# Altium schematic sheet SAM70.SchDoc(U_SAM70)
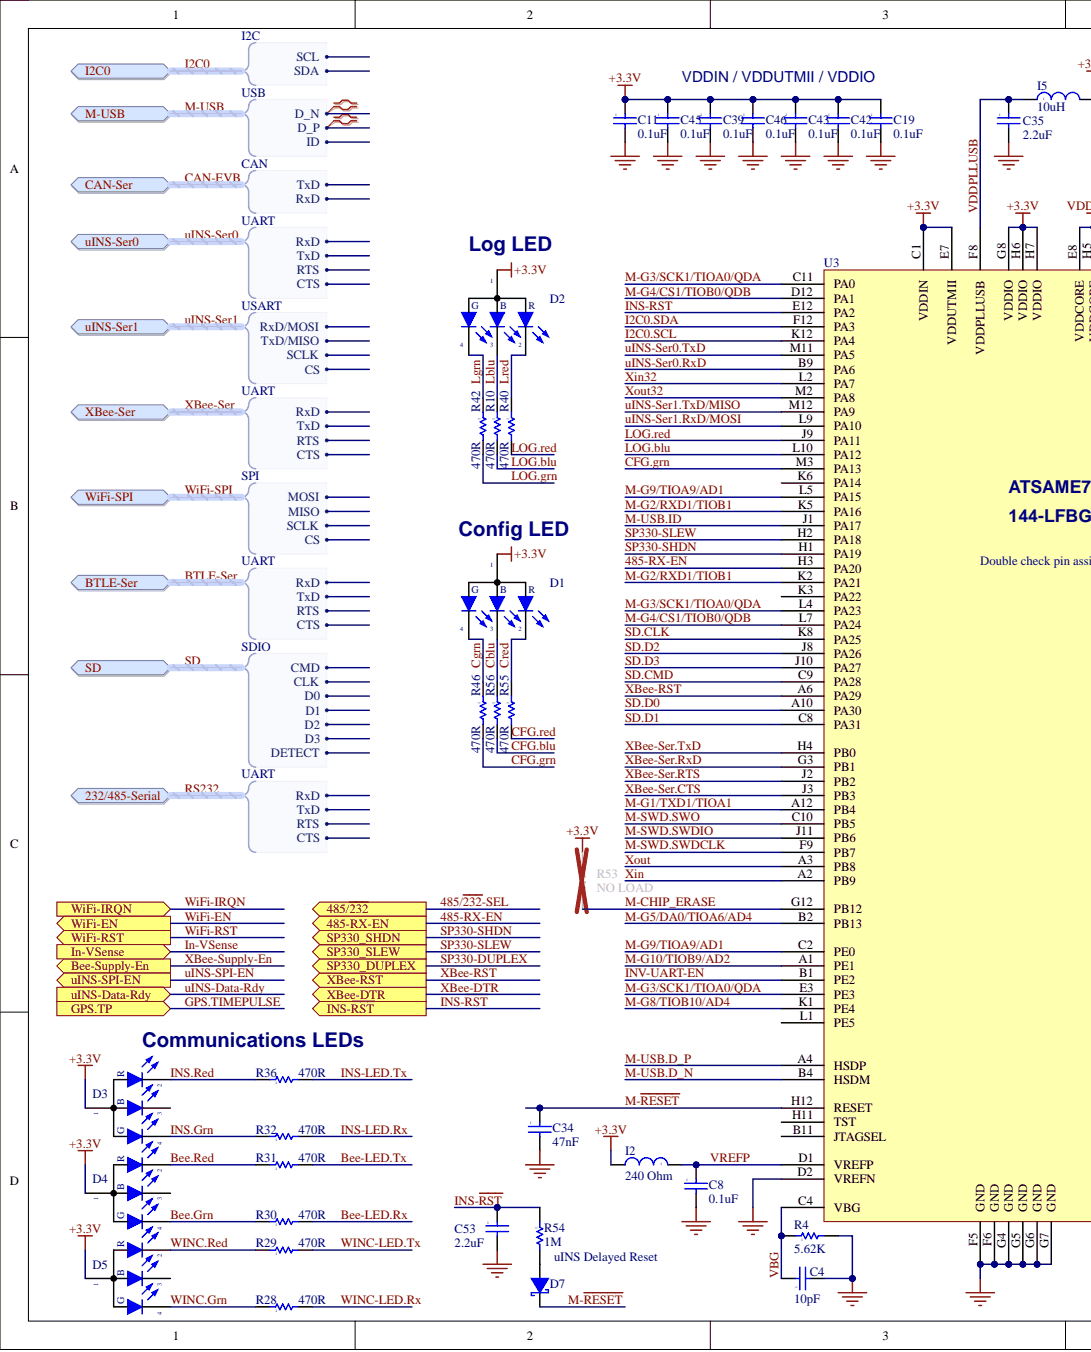
[diagram p0: SAM70.SchDoc, left]
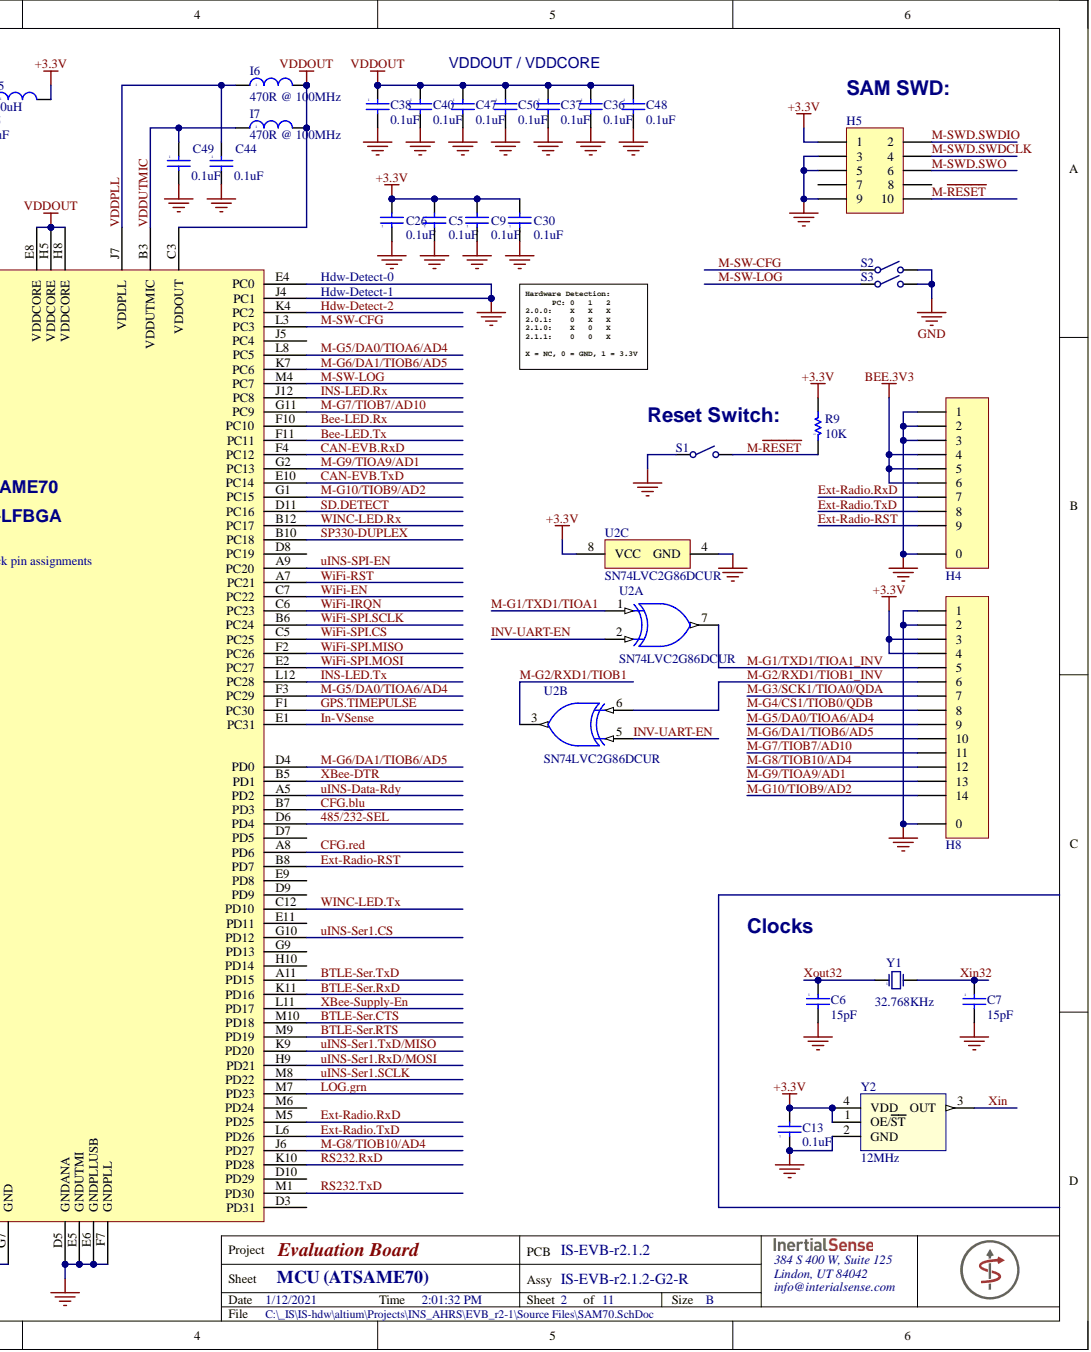
[diagram p1: SAM70.SchDoc, right]

## Parts
C4  value 10pF  Capacitance: 10pF  [0402]  (hidden comment: Cap)
C5  value 0.1uF  [0402]  (hidden comment: Cap)
C6  value 15pF  Capacitance: 15pF  [0402]  (hidden comment: Cap)
C7  value 15pF  Capacitance: 15pF  [0402]  (hidden comment: Cap)
C8  value 0.1uF  [0201]  (hidden comment: Cap)
C9  value 0.1uF  [0402]  (hidden comment: Cap)
C11  value 0.1uF  [0201]  (hidden comment: Cap)
C13  value 0.1uF  [0402]  (hidden comment: Cap)
C19  value 0.1uF  [0201]  (hidden comment: Cap)
C26  value 0.1uF  [0402]  (hidden comment: Cap)
C30  value 0.1uF  [0402]  (hidden comment: Cap)
C34  value 47nF  Capacitance: 47nF  [0402]  (hidden comment: Cap)
C35  Capacitance: 2.2uF  [0603]  (hidden comment: Cap)
C36  value 0.1uF  [0201]  (hidden comment: Cap)
C37  value 0.1uF  [0201]  (hidden comment: Cap)
C38  value 0.1uF  [0201]  (hidden comment: Cap)
C39  value 0.1uF  [0201]  (hidden comment: Cap)
C40  value 0.1uF  [0201]  (hidden comment: Cap)
C42  value 0.1uF  [0201]  (hidden comment: Cap)
C43  value 0.1uF  [0201]  (hidden comment: Cap)
C44  value 0.1uF  [0201]  (hidden comment: Cap)
C45  value 0.1uF  [0201]  (hidden comment: Cap)
C46  value 0.1uF  [0201]  (hidden comment: Cap)
C47  value 0.1uF  [0201]  (hidden comment: Cap)
C48  value 0.1uF  [0201]  (hidden comment: Cap)
C49  value 0.1uF  [0201]  (hidden comment: Cap)
C50  value 0.1uF  [0201]  (hidden comment: Cap)
C53  Capacitance: 2.2uF  [0603]  (hidden comment: Cap)
D1  LED-RGB  [LED0404]  (value not drawn)
D2  LED-RGB  [LED0404]  (value not drawn)
D3  LED-RGB  [LED0404]  (value not drawn)
D4  LED-RGB  [LED0404]  (value not drawn)
D5  LED-RGB  [LED0404]  (value not drawn)
D7  Diode - Schottky  [D0603B]  (value not drawn)
H4  Hdr - 9pin - mount  [SM09B-GHS-TB(LF)(SN)]  (value not drawn)
H5  Hdr - 5x2pin  [FTSH-105-01-L-DV-K-A-P]  (value not drawn)
H8  Hdr - 14pin - mount  [SM14B-GHS-TB(LF)(SN)]  (value not drawn)
I2  value 240 Ohm  [0201]  (hidden comment: Inductor)
I5  Inductance: 10uH  [0806]  (hidden comment: Inductor)
I6  value 470R @ 100MHz  Frequency: 100MHz  Impedance: 470R  [0402]  (hidden comment: Inductor)
I7  value 470R @ 100MHz  Frequency: 100MHz  Impedance: 470R  [0402]  (hidden comment: Inductor)
R4  value 5.62K  [R0402]  (hidden comment: Res)
R9  value 10K  [R0402]  (hidden comment: Res)
R10  value 470R  Resistance: 470R  [R0402]  (hidden comment: Res)
R28  value 470R  Resistance: 470R  [R0402]  (hidden comment: Res)
R29  value 470R  Resistance: 470R  [R0402]  (hidden comment: Res)
R30  value 470R  Resistance: 470R  [R0402]  (hidden comment: Res)
R31  value 470R  Resistance: 470R  [R0402]  (hidden comment: Res)
R32  value 470R  Resistance: 470R  [R0402]  (hidden comment: Res)
R36  value 470R  Resistance: 470R  [R0402]  (hidden comment: Res)
R40  value 470R  Resistance: 470R  [R0402]  (hidden comment: Res)
R42  value 470R  Resistance: 470R  [R0402]  (hidden comment: Res)
R46  value 470R  Resistance: 470R  [R0402]  (hidden comment: Res)
R53  value NO LOAD  Resistance (Ohms): NO LOAD  [R0402]  (hidden comment: Res)
R54  value 1M  [R0402]  (hidden comment: Res)
R55  value 470R  Resistance: 470R  [R0402]  (hidden comment: Res)
R56  value 470R  Resistance: 470R  [R0402]  (hidden comment: Res)
S1  SPST Switch  [PTS810]  (value not drawn)
S2  SPST Switch  [PTS810]  (value not drawn)
S3  SPST Switch  [PTS810]  (value not drawn)
U2  SN74LVC2G86DCUR  [DCU0008A_N]  (parts A,B,C)
U3  ATSAME70-144  [BGA144C80P12X12_1000X1000X140]
Y1  value 32.768KHz  [CRYMP2012X06L]  (hidden comment: Crystal)
Y2  value 12MHz  Frequency: 12MHz  [ABM10_2.5_x_2.0]  (hidden comment: Oscillator2)

## Ports
232/485-Serial, 232/485-Serial.CTS, 232/485-Serial.RTS, 232/485-Serial.RxD, 232/485-Serial.TxD, 485-RX-EN, 485/~{232}, Bee-Supply-En, BTLE-Ser, BTLE-Ser.CTS, BTLE-Ser.RTS, BTLE-Ser.RxD, BTLE-Ser.TxD, CAN-Ser, CAN-Ser.RxD, CAN-Ser.TxD, GPS.TP, I2C0, I2C0.SCL, I2C0.SDA, In-VSense, INS-~{RST}, M-USB, M-USB.D_N, M-USB.D_P, M-USB.ID, SD, SD.CLK, SD.CMD, SD.D0, SD.D1, SD.D2, SD.D3, SD.DETECT, SP330_DUPLEX, uINS-Data-Rdy, uINS-Ser0, uINS-Ser0.CTS, uINS-Ser0.RTS, uINS-Ser0.RxD, uINS-Ser0.TxD, uINS-Ser1, uINS-Ser1.CS, uINS-Ser1.RxD/MOSI, uINS-Ser1.SCLK, uINS-Ser1.TxD/MISO, uINS-SPI-EN, WiFi-EN, WiFi-IRQN, WiFi-RST, WiFi-SPI, WiFi-SPI.CS, WiFi-SPI.MISO, WiFi-SPI.MOSI, WiFi-SPI.SCLK, XBee-DTR, XBee-RST, XBee-Ser, XBee-Ser.CTS, XBee-Ser.RTS, XBee-Ser.RxD, XBee-Ser.TxD, ~{SP330_SHDN}, ~{SP330_SLEW}

## Nets
+3.3V: C5.2 C9.2 C11.1 C13.2 C19.1 C26.2 C30.2 C39.1 C42.1 C43.1 C45.1 C46.1 D1.1 D2.1 D3.1 D4.1 D5.1 H5.1 H8.3 H8.4 I2.2 I5.2 R9.2 R53.2 U2.8(VCC) U3.C1(VDDIN) U3.E7(VDDUTMII) U3.G8(VDDIO) U3.H6(VDDIO) U3.H7(VDDIO) Y2.1(OE/ST) Y2.4(VDD)
GND: C4.2 C5.1 C6.2 C7.2 C8.2 C9.1 C11.2 C13.1 C19.2 C26.1 C30.1 C34.2 C35.2 C36.2 C37.2 C38.2 C39.2 C40.2 C42.2 C43.2 C44.2 C45.2 C46.2 C47.2 C48.2 C49.2 C50.2 C53.2 H4.0 H4.1 H4.2 H4.3 H5.3 H5.5 H5.9 H8.0 H8.1 H8.2 R4.2 S1.1 S2.2 S3.2 U2.4(GND) U3.D2(VREFN) U3.D5(GNDANA) U3.E4(PC0) U3.E5(GNDUTMI) U3.E6(GNDPLLUSB) U3.F5(GND) U3.F6(GND) U3.F7(GNDPLL) U3.G4(GND) U3.G5(GND) U3.G6(GND) U3.G7(GND) U3.J4(PC1) Y2.2(GND)
VDDOUT: C36.1 C37.1 C38.1 C40.1 C47.1 C48.1 C50.1 I6.2 I7.2 U3.C3(VDDOUT) U3.E8(VDDCORE) U3.H5(VDDCORE) U3.H8(VDDCORE)
485-RX-EN: U3.H3(PA20) ; port 485-RX-EN
485/~{232}-SEL: U3.D6(PD4) ; port 485/~{232}
~{SP330-SHDN}: U3.H1(PA19) ; port ~{SP330_SHDN}
Bee-LED.Rx: R30.2 U3.F10(PC10)
Bee-LED.Tx: R31.2 U3.F11(PC11)
BEE.3V3: D4.2 D4.4 H4.4 H4.5 H4.6 R30.1 R31.1  [also labelled Bee.Grn, Bee.Red]
BTLE-Ser.CTS: U3.M10(PD18) ; port BTLE-Ser
BTLE-Ser.RTS: U3.M9(PD19) ; port BTLE-Ser
BTLE-Ser.RxD: U3.K11(PD16) ; port BTLE-Ser
BTLE-Ser.TxD: U3.A11(PD15) ; port BTLE-Ser
CAN-EVB.RxD: U3.F4(PC12) ; port CAN-Ser
CAN-EVB.TxD: U3.E10(PC14) ; port CAN-Ser
Cblu: D1.3 R56.1
CFG.blu: R56.2 U3.B7(PD3)
CFG.grn: R46.2 U3.M3(PA13)
CFG.red: R55.2 U3.A8(PD6)
Cgrn: D1.4 R46.1
Cred: D1.2 R55.1
Ext-Radio-RST: H4.9 U3.B8(PD7)
Ext-Radio.RxD: H4.7 U3.M5(PD25)
Ext-Radio.TxD: H4.8 U3.L6(PD26)
GPS.TIMEPULSE: U3.F1(PC30) ; port GPS.TP
Hdw-Detect-2: U3.K4(PC2)
I2C0.SCL: U3.K12(PA4) ; port I2C0
I2C0.SDA: U3.F12(PA3) ; port I2C0
In-VSense: U3.E1(PC31) ; port In-VSense
INS-LED.Rx: R32.2 U3.J12(PC8)
INS-LED.Tx: R36.2 U3.L12(PC28)
INS-~{RST}: C53.1 R54.1 U3.E12(PA2) ; port INS-~{RST}
INS.Grn: D3.4 R32.1
INS.Red: D3.2 R36.1
INV-UART-EN: U2.2 U2.5 U3.B1(PE2)
Lblu: D2.3 R10.1
Lgrn: D2.4 R42.1
LOG.blu: R10.2 U3.L10(PA12)
LOG.grn: R42.2 U3.M7(PD23)
LOG.red: R40.2 U3.J9(PA11)
Lred: D2.2 R40.1
M-CHIP_ERASE: R53.1 U3.G12(PB12)
M-G1/TXD1/TIOA1: U2.1 U3.A12(PB4)
M-G1/TXD1/TIOA1_INV: H8.5 U2.7
M-G2/RXD1/TIOB1: U2.3 U3.K2(PA21) U3.K5(PA16)
M-G2/RXD1/TIOB1_INV: H8.6 U2.6
M-G3/SCK1/TIOA0/QDA: H8.7 U3.C11(PA0) U3.E3(PE3) U3.L4(PA23)
M-G4/CS1/TIOB0/QDB: H8.8 U3.D12(PA1) U3.L7(PA24)
M-G5/DA0/TIOA6/AD4: H8.9 U3.B2(PB13) U3.F3(PC29) U3.L8(PC5)
M-G6/DA1/TIOB6/AD5: H8.10 U3.D4(PD0) U3.K7(PC6)
M-G7/TIOB7/AD10: H8.11 U3.G11(PC9)
M-G8/TIOB10/AD4: H8.12 U3.J6(PD27) U3.K1(PE4)
M-G9/TIOA9/AD1: H8.13 U3.C2(PE0) U3.G2(PC13) U3.L5(PA15)
M-G10/TIOB9/AD2: H8.14 U3.A1(PE1) U3.G1(PC15)
M-~{RESET}: C34.1 D7.C H5.10 R9.1 S1.2 U3.H12(RESET)
M-SW-CFG: S2.1 U3.L3(PC3)
M-SW-LOG: S3.1 U3.M4(PC7)
M-SWD.SWDCLK: H5.4 U3.F9(PB7)
M-SWD.SWDIO: H5.2 U3.J11(PB6)
M-SWD.SWO: H5.6 U3.C10(PB5)
M-USB.D_N: U3.B4(HSDM) ; port M-USB
M-USB.D_P: U3.A4(HSDP) ; port M-USB
M-USB.ID: U3.J1(PA17) ; port M-USB
RS232.RxD: U3.K10(PD28) ; port 232/485-Serial
RS232.TxD: U3.M1(PD30) ; port 232/485-Serial
SD.CLK: U3.K8(PA25) ; port SD
SD.CMD: U3.C9(PA28) ; port SD
SD.D0: U3.A10(PA30) ; port SD
SD.D1: U3.C8(PA31) ; port SD
SD.D2: U3.J8(PA26) ; port SD
SD.D3: U3.J10(PA27) ; port SD
SD.DETECT: U3.D11(PC16) ; port SD
SP330-DUPLEX: U3.B10(PC18) ; port SP330_DUPLEX
SP330-SLEW: U3.H2(PA18) ; port ~{SP330_SLEW}
uINS-Data-Rdy: U3.A5(PD2) ; port uINS-Data-Rdy
uINS-Ser0.RxD: U3.B9(PA6) ; port uINS-Ser0
uINS-Ser0.TxD: U3.M11(PA5) ; port uINS-Ser0
uINS-Ser1.CS: U3.G10(PD12) ; port uINS-Ser1
uINS-Ser1.RxD/MOSI: U3.H9(PD21) U3.L9(PA10) ; port uINS-Ser1
uINS-Ser1.SCLK: U3.M8(PD22) ; port uINS-Ser1
uINS-Ser1.TxD/MISO: U3.K9(PD20) U3.M12(PA9) ; port uINS-Ser1
uINS-SPI-EN: U3.A9(PC20) ; port uINS-SPI-EN
VBG: C4.1 R4.1 U3.C4(VBG)
VDDPLL: C44.1 I6.1 U3.J7(VDDPLL)
VDDPLLUSB: C35.1 I5.1 U3.F8(VDDPLLUSB)
VDDUTMIC: C49.1 I7.1 U3.B3(VDDUTMIC)
VREFP: C8.1 I2.1 U3.D1(VREFP)
WiFi-EN: U3.C7(PC22) ; port WiFi-EN
WiFi-IRQN: U3.C6(PC23) ; port WiFi-IRQN
WiFi-RST: U3.A7(PC21) ; port WiFi-RST
WiFi-SPI.CS: U3.C5(PC25) ; port WiFi-SPI
WiFi-SPI.MISO: U3.F2(PC26) ; port WiFi-SPI
WiFi-SPI.MOSI: U3.E2(PC27) ; port WiFi-SPI
WiFi-SPI.SCLK: U3.B6(PC24) ; port WiFi-SPI
WINC-LED.Rx: R28.2 U3.B12(PC17)
WINC-LED.Tx: R29.2 U3.C12(PD10)
WINC.Grn: D5.4 R28.1
WINC.Red: D5.2 R29.1
XBee-DTR: U3.B5(PD1) ; port XBee-DTR
XBee-RST: U3.A6(PA29) ; port XBee-RST
XBee-Ser.CTS: U3.J3(PB3) ; port XBee-Ser
XBee-Ser.RTS: U3.J2(PB2) ; port XBee-Ser
XBee-Ser.RxD: U3.G3(PB1) ; port XBee-Ser
XBee-Ser.TxD: U3.H4(PB0) ; port XBee-Ser
XBee-Supply-En: U3.L11(PD17) ; port Bee-Supply-En
Xin: U3.A2(PB9) Y2.3(OUT)
Xin32: C7.1 U3.L2(PA7) Y1.2
Xout: U3.A3(PB8)
Xout32: C6.1 U3.M2(PA8) Y1.1
NetD3_3: D3.3
NetD4_3: D4.3
NetD5_3: D5.3
NetD7_A: D7.A R54.2
NetH5_7: H5.7
NetH5_8: H5.8
NetU3_B11: U3.B11(JTAGSEL)
NetU3_D3: U3.D3(PD31)
NetU3_D7: U3.D7(PD5)
NetU3_D8: U3.D8(PC19)
NetU3_D9: U3.D9(PD9)
NetU3_D10: U3.D10(PD29)
NetU3_E9: U3.E9(PD8)
NetU3_E11: U3.E11(PD11)
NetU3_G9: U3.G9(PD13)
NetU3_H10: U3.H10(PD14)
NetU3_H11: U3.H11(TST)
NetU3_J5: U3.J5(PC4)
NetU3_K3: U3.K3(PA22)
NetU3_K6: U3.K6(PA14)
NetU3_L1: U3.L1(PE5)
NetU3_M6: U3.M6(PD24)
RS232.CTS: ; port 232/485-Serial
RS232.RTS: ; port 232/485-Serial
uINS-Ser0.CTS: ; port uINS-Ser0
uINS-Ser0.RTS: ; port uINS-Ser0

## Library data of the parts
C4 = Cap: CAP CER 10PF 50V C0G 0402; Manufacturer: TDK; Manufacturer Part Number: C1005C0G1H100D050BA; Dielectric Material: Ceramic; Temperature Coefficient: 30ppm/°C; Case Code (Imperial): 0402; Case Code (Metric): 1005; Case/Package: 0402; Category: Capacitors; Component Kind: Standard; Depth: 0.5mm; Dielectric: C0G; Height: 0.5mm; LatestRevisionDate: 17-Jul-2002; LatestRevisionNote: Re-released for DXP Platform.; Lead Free: Lead Free; Length: 1mm; Library Name: General.SchLib; Max Operating Temperature: 125°C; Min Operating Temperature: -55°C; Mount: Surface Mount; Number of Pins: 2; Package Quantity: 1; Packaging: Tape and Reel; Pricing 1: 1=0.1, 10=0.027, 100=0.012, 500=0.00858, 1000=0.00674, 2500=0.00612, 5000=0.00564 (USD); Published: 8-Jun-2000; Radiation Hardening: No; REACH SVHC: No SVHC; Signal Integrity: Cap; Simulation: CAP; Stock 1: 0; Supplier 1: Digi-Key; Supplier Part Number 1: 445-1235-6-ND; Termination: SMD/SMT; Thickness: 0.022inch; Voltage: 50V; Voltage Rating: 50V; Voltage Rating (DC): 50V; Weight: 2.3E-05oz; Width: 0.02inch; Publisher: Altium Limited
C5 C9 C13 C26 C30 = Cap: CAP CER .10UF 50V X7R 10% 0402; Manufacturer: TDK Corporation; Manufacturer Part Number: C1005X7R1H104K; Capacitance: 0.1µF; Applications: General Purpose; Category: Capacitors; Component Kind: Standard; ComponentLink1Description: http://www.tdk.co.jp/tefe02/e412_c.pdf; ComponentLink1URL: http://www.tdk.co.jp/tefe02/e412_c.pdf; Features: -; Height: -; LatestRevisionDate: 17-Jul-2002; LatestRevisionNote: Re-released for DXP Platform.; Lead Spacing: -; Lead Style: -; Library Name: General.SchLib; Mounting Type: Surface Mount, MLCC; Operating Temperature: -55°C ~ 125°C; Package / Case: 0402 (1005 Metric); Packaging: Cut Tape (CT); Pricing 1: 1=0.3, 10=0.2, 100=0.08, 250=0.05752, 500=0.041, 1000=0.03 (USD); Published: 8-Jun-2000; Ratings: -; RoHS: RoHS Compliant; Signal Integrity: Cap; Simulation: CAP; Size / Dimension: 0.039" L x 0.020" W (1.00mm x 0.50mm); Stock 1: 174080; Supplier 1: Digi-Key; Supplier Part Number 1: 445-5932-1-ND; Temperature Coefficient: X7R; Thickness: 0.022" (0.55mm); Tolerance: ±10%; Voltage - Rated: 50V; Publisher: Altium Limited
C6 C7 = Cap: CAP CER 15PF 16V C0G/NP0 0402; Manufacturer: KEMET; Manufacturer Part Number: C0402C150J4GACTU; Dielectric: C0G; Dielectric Material: Ceramic; Dissipation Factor: 0.1%; Tolerance: 5%; Case Code (Imperial): 0402; Case Code (Metric): 1005; Case/Package: 0402; Category: Capacitors; Component Kind: Standard; Depth: 0.5mm; Height: 0.5mm; LatestRevisionDate: 17-Jul-2002; LatestRevisionNote: Re-released for DXP Platform.; Lead Free: Lead Free; Lead Pitch: 0.3mm; Length: 1mm; Library Name: General.SchLib; Max Operating Temperature: 125°C; Min Operating Temperature: -55°C; Mount: Surface Mount; Package Quantity: 1; Packaging: Tape and Reel; Pricing 1: 1=0.1, 10=0.028, 100=0.0127, 500=0.00906, 1000=0.00712, 2500=0.00647, 5000=0.00595 (USD); Published: 8-Jun-2000; REACH SVHC: No SVHC; Signal Integrity: Cap; Simulation: CAP; Stock 1: 94634; Supplier 1: Digi-Key; Supplier Part Number 1: 399-8947-6-ND; Termination: SMD/SMT; Thickness: 0.022inch; Voltage Rating: 16V; Voltage Rating (DC): 16V; Width: 0.02inch; Publisher: Altium Limited
C8 C11 C19 C36-C40 C42-C50 = Cap: CAP CER 0.1UF 25V X5R 0201; Manufacturer: Murata; Manufacturer Part Number: GRM033R6YA104KE14D; Capacitance: 100nF; Tolerance: 10%; Voltage Rating: 35V; Case Code (Imperial): 0201; Case Code (Metric): 0603; Case/Package: 0201; Category: Capacitors; Component Kind: Standard; Dielectric: X5R; Height: 0.3mm; LatestRevisionDate: 17-Jul-2002; LatestRevisionNote: Re-released for DXP Platform.; Length: 0.024inch; Library Name: General.SchLib; Max Operating Temperature: 85°C; Min Operating Temperature: -55°C; Mount: Surface Mount; Package Quantity: 15000; Packaging: Tape and Reel; Pricing 1: 1=0.1, 10=0.066, 100=0.0296, 500=0.02114, 1000=0.0166, 2500=0.01509, 5000=0.01389 (USD); Published: 8-Jun-2000; Signal Integrity: Cap; Simulation: CAP; Stock 1: 150000; Supplier 1: Digi-Key; Supplier Part Number 1: 490-10430-1-ND; Termination: SMD/SMT; Thickness: 0.013inch; Voltage Rating (DC): 35V; Weight: 1.2E-05oz; Width: 0.3mm; Publisher: Altium Limited
C34 = Cap: CAP CER 0.047UF 16V Y5V 0402; Manufacturer: Yageo; Manufacturer Part Number: CC0402ZRY5V7BB473; Dielectric Material: Ceramic; Insulation Resistance: 100GR; Tolerance: 80%; Case Code (Imperial): 0402; Case Code (Metric): 1005; Case/Package: 0402; Category: Capacitors; Component Kind: Standard; Composition: Ceramic; Depth: 0.5mm; Dielectric: Y5V; Halogen Free: Halogen Free; Height: 0.5mm; LatestRevisionDate: 17-Jul-2002; LatestRevisionNote: Re-released for DXP Platform.; Lead Free: Lead Free; Length: 1mm; Library Name: General.SchLib; Max Operating Temperature: 85°C; Min Operating Temperature: -30°C; Mount: Surface Mount; Number of Pins: 2; Package Quantity: 10000; Packaging: Tape and Reel; Pricing 1: 1=0.1, 10=0.037, 100=0.0165, 500=0.01178, 1000=0.00926, 2500=0.00842, 5000=0.00774 (USD); Published: 8-Jun-2000; Radiation Hardening: No; REACH SVHC: No SVHC; Signal Integrity: Cap; Simulation: CAP; Stock 1: 0; Supplier 1: Digi-Key; Supplier Part Number 1: 311-1046-6-ND; Termination: SMD/SMT; Thickness: 0.022inch; Voltage Rating: 16V; Voltage Rating (DC): 16V; Width: 0.02inch; Publisher: Altium Limited
C35 C53 = Cap: CAP CER 2.2UF 6.3V X5R 0603; Manufacturer: Samsung; Manufacturer Part Number: CL10A225KQ8NNWC; Tolerance: 10%; Case Code (Imperial): 0603; Case Code (Metric): 1608; Case/Package: 0603; Component Kind: Standard; ComponentLink1Description: https://datasheet.ciiva.com/25798/cl21b225kafnfne-25798721.pdf; ComponentLink1URL: https://datasheet.ciiva.com/25798/cl21b225kafnfne-25798721.pdf; Length: 0.063inch; Library Name: General.SchLib; Max Operating Temperature: 85°C; Min Operating Temperature: -55°C; Mount: Surface Mount; Package Quantity: 4000; Packaging: Cut Tape; Pricing 1: 1=0.13, 10=0.096, 100=0.0429, 500=0.03062, 1000=0.02406 (USD); Signal Integrity: Cap; Simulation: CAP; Stock 1: 40000; Supplier 1: Digi-Key; Supplier Part Number 1: 1276-1886-1-ND; Thickness: 0.035inch; Voltage Rating: 6.3V; Width: 0.031inch
D1-D5 = LED-RGB: LED RGB DIFFUSED 0404 SMD; Manufacturer: Rohm Semiconductor; Manufacturer Part Number: SMLP34RGB2W3; Color: Red, Green, Blue (RGB); Current - Test: 5mA Red, 5mA Green, 5mA Blue; Lens Color: White; Lens Style/Size: Rectangle with Flat Top, 1.00mm x 0.60mm; Millicandela Rating: 35mcd Red, 110mcd Green, 45mcd Blue; Voltage - Forward (Vf) (Typ): 2.1V Red, 3.1V Green, 3V Blue; Wavelength - Dominant: 624nm Red, 527nm Green, 470nm Blue; Category: Optoelectronics; Component Kind: Standard; ComponentLink1Description: http://rohmfs.rohm.com/en/products/databook/datasheet/opto/led/chip_multi/smlp34.pdf; ComponentLink1URL: http://rohmfs.rohm.com/en/products/databook/datasheet/opto/led/chip_multi/smlp34.pdf; Features: -; Height (Max): 0.25mm; LatestRevisionDate: 08-Jul-2005; LatestRevisionNote: Mech Layer 1 removed.; Lens Transparency: Diffused; Library Name: General.SchLib; Mounting Type: Surface Mount; Package / Case: 0404 (1010 Metric); PackageReference: LED-0; Packaging: Tape & Reel (TR); Pricing 1: 5000=0.297, 10000=0.2926, 25000=0.286, 50000=0.275, 125000=0.264 (USD); Published: 8-Jun-2000; RoHS: RoHS Compliant; Size / Dimension: 1.00mm L x 1.00mm W; Stock 1: 55000; Supplier 1: Digi-Key; Supplier Device Package: PICOLED; Supplier Part Number 1: SMLP34RGB2W3TR-ND; Viewing Angle: -; Wavelength - Peak: -; Publisher: Altium Limited
D7 = Diode - Schottky: DIODE SCHOTTKY 30V 2A 0603; Manufacturer: Vishay; Manufacturer Part Number: VSKY20301608-G4-08; Component Kind: Standard; ComponentLink1Description: http://www.mouser.com/ds/2/427/vsky20301608-777242.pdf; ComponentLink1URL: http://www.mouser.com/ds/2/427/vsky20301608-777242.pdf; Library Name: General.SchLib; Pricing 1: 1=0.38, 10=0.305, 25=0.2792, 100=0.2076, 250=0.1882, 500=0.15574, 1000=0.1168, 2500=0.10707 (USD); Simulation: SKYDIODE; Stock 1: 13064; Supplier 1: Digi-Key; Supplier Part Number 1: VSKY20301608-G4-08GICT-ND
H4 = Hdr - 9pin - mount: CONN HEADER GH SIDE 9POS 1.25MM; Manufacturer: JST; Connector Type: Outer Shroud Contact; Number of Contacts: 9; Pitch: 0.049inch; Colour: Natural; Component Kind: Standard; Contact Material: Bronze; Contact Plating: Tin; Fastening Type: Ramp; Housing Colour: Natural; Housing Material: Nylon; Lead Free: Lead Free; Library Name: Connectors.SchLib; Manufacturer Part Number: SM09B-GHS-TB(LF)(SN); Mount: Surface Mount; Number of Positions: 9; Number of Rows: 1; Packaging: Cut Tape; Stock 1: 0; Supplier 1: Digi-Key; Supplier Part Number 1: 455-1571-2-ND; Termination: Solder
H5 = Hdr - 5x2pin: CONN HEADER 10POS DUAL .05" SMD; Manufacturer: Samtec; Gender: Male; Number of Contacts: 10; Pitch: 1.27mm; Component Kind: Standard; ComponentLink1Description: http://www.samtec.com/documents/webfiles/pdf/FTSH_SMT.pdf; ComponentLink1URL: http://www.samtec.com/documents/webfiles/pdf/FTSH_SMT.pdf; Contact Material: Bronze; Contact Plating: Gold; Depth: 3.43mm; Housing Colour: Black; Housing Material: Polymer; Lead Free: Lead Free; Length: 6.35mm; Library Name: Connectors.SchLib; Manufacturer Part Number: FTSH-105-01-L-DV-K-A-P; Max Operating Temperature: 125°C; Min Operating Temperature: -55°C; Number of Rows: 2; Orientation: Straight; Packaging: Bulk; Pricing 1: 1=4.15, 92=3.48598, 184=3.32, 552=2.82199, 1012=2.407 (USD); Radiation Hardening: No; Stock 1: 3679; Supplier 1: Digi-Key; Supplier Part Number 1: SAM9440-ND; Termination: Solder
H8 = Hdr - 14pin - mount: CONN HEADER GH SIDE 14POS 1.25MM; Manufacturer: JST; Connector Type: Outer Shroud Contact; Current Rating: 1A; Gender: Male; Number of Contacts: 14; Pitch: 0.049inch; Voltage Rating (AC): 50V; Wire/Cable Gauge: 26AWG; Colour: Natural; Component Kind: Standard; Contact Material: Bronze; Contact Plating: Tin; Fastening Type: Ramp; Housing Colour: Natural; Housing Material: Nylon; Lead Free: Lead Free; Library Name: Connectors.SchLib; Manufacturer Part Number: SM14B-GHS-TB(LF)(SN); Max Operating Temperature: 85°C; Min Operating Temperature: -25°C; Mount: Surface Mount; Number of Positions: 14; Number of Rows: 1; Packaging: Tape and Reel; REACH SVHC: No SVHC; Stock 1: 0; Supplier 1: Digi-Key; Supplier Part Number 1: 455-1576-6-ND; Termination: Solder
I2 = Inductor: FERRITE BEAD 240 OHM 0201 1LN; Manufacturer: TDK Corporation; Manufacturer Part Number: MMZ0603S241HT000; Current Rating (Max): 420mA; DC Resistance (DCR) (Max): 320 mOhm; Filter Type: Signal Line; Impedance @ Frequency: 240 Ohm @ 100MHz; Number of Lines: 1; Category: Filters; Component Kind: Standard; ComponentLink1Description: https://product.tdk.com/info/en/catalog/datasheets/beads_commercial_signal_mmz0603_en.pdf; ComponentLink1URL: https://product.tdk.com/info/en/catalog/datasheets/beads_commercial_signal_mmz0603_en.pdf; Height (Max): 0.013" (0.33mm); Library Name: General.SchLib; Mounting Type: Surface Mount; Operating Temperature: -55°C ~ 125°C; Package / Case: 0201 (0603 Metric); Packaging: Cut Tape (CT); Pricing 1: 1=0.13, 10=0.109, 100=0.0605, 250=0.0484, 500=0.04356, 1000=0.0363, 5000=0.03388 (USD); Ratings: -; RoHS: RoHS Compliant; Size / Dimension: 0.024" L x 0.012" W (0.60mm x 0.30mm); Stock 1: 16894; Supplier 1: Digi-Key; Supplier Part Number 1: 445-172341-1-ND
I5 = Inductor: FIXED IND 10UH 350MA 1.066 OHM; Manufacturer: Taiyo Yuden; Manufacturer Part Number: CBC2016T100M; Core Material: Ferrite; DC Resistance (DCR): 1.066R; Inductance Tolerance: 20%; Saturation Current: 380mA; Self Resonant Frequency: 32MHz; Shielding: Unshielded; Test Frequency: 2.52MHz; Case Code (Imperial): 0806; Case Code (Metric): 2016; Case/Package: 0806; Component Kind: Standard; ComponentLink1Description: https://datasheet.ciiva.com/8505/brc2016t100k-ss-8505634.pdf; ComponentLink1URL: https://datasheet.ciiva.com/8505/brc2016t100k-ss-8505634.pdf; ComponentLink2Description: https://datasheet.ciiva.com/7648/wound07-e-7648376.pdf; ComponentLink2URL: https://datasheet.ciiva.com/7648/wound07-e-7648376.pdf; ComponentLink3Description: https://datasheet.ciiva.com/6701/wound07-e-6701195.pdf; ComponentLink3URL: https://datasheet.ciiva.com/6701/wound07-e-6701195.pdf; ComponentLink4Description: https://datasheet.ciiva.com/6701/wound07-e-6701196.pdf; ComponentLink4URL: https://datasheet.ciiva.com/6701/wound07-e-6701196.pdf; ComponentLink5Description: https://datasheet.ciiva.com/6701/wound07-e-6701197.pdf; ComponentLink5URL: https://datasheet.ciiva.com/6701/wound07-e-6701197.pdf; Composition: Wirewound; Current Rating: 350mA; DC Current: 350mA; Depth: 1.8mm; Halogen Free: Halogen Free; Height: 1.6mm; Height - Seated (Max): 0.071inch; Lead Free: Lead Free; Length: 0.079inch; Library Name: General.SchLib; Max DC Current: 350mA; Max Operating Temperature: 105°C; Military Standard: Not; Min Operating Temperature: -40°C; Mount: Surface Mount; Number of Pins: 2; Package Quantity: 2000; Packaging: Tape and Reel; Pricing 1: 1=0.25, 10=0.221, 100=0.1518, 500=0.12972, 1000=0.09936 (USD); Radiation Hardening: No; REACH SVHC: Unknown; Stock 1: 43283; Supplier 1: Digi-Key; Supplier Part Number 1: 587-1606-1-ND; Termination: SMD/SMT; Tolerance: 20%; Width: 0.063inch
I6 I7 = Inductor: FERRITE BEAD 470 OHM 0402; Manufacturer: Taiyo Yuden; Manufacturer Part Number: BK1005HM471-T; DC Resistance (DCR): 450mR; Max Current Rating: 250mA; Number of Lines: 1; Shielding: Unshielded; Tolerance: 25%; Case Code (Imperial): 0402; Case Code (Metric): 1005; Case/Package: 0402; Component Kind: Standard; Core Material: Ferrite; Depth: 0.55mm; Halogen Free: Halogen Free; Height: 0.022inch; Lead Free: Lead Free; Length: 0.039inch; Library Name: General.SchLib; Max DC Current: 250mA; Max Operating Temperature: 125°C; Min Operating Temperature: -55°C; Mount: Surface Mount; Number of Pins: 2; Package Quantity: 10; Packaging: Tape and Reel; Pricing 1: 1=0.1, 10=0.041, 25=0.0364, 50=0.033, 100=0.0297, 250=0.0218, 500=0.01584, 1000=0.01551, 5000=0.01056 (USD); Stock 1: 18500; Supplier 1: Digi-Key; Supplier Part Number 1: 587-1838-6-ND; Termination: SMD/SMT; Test Frequency: 100MHz; Width: 0.02inch
R4 = Res: RES SMD 5.62K OHM 1% 1/10W 0402; Manufacturer: Panasonic; Manufacturer Part Number: ERJ-2RKF5621X; Max Power Dissipation: 100mW; Resistance: 5.62kR; Temperature Coefficient: 100ppm/°C; Voltage Rating: 50V; Case Code (Imperial): 0402; Case Code (Metric): 1005; Case/Package: 0402; Component Kind: Standard; Composition: Thick Film; Contact Plating: Tin; Depth: 0.5mm; Features: Automotive AEC-Q200; Height: 0.016inch; Lead Free: Lead Free; Length: 1mm; Library Name: General.SchLib; Max Operating Temperature: 155°C; Military Standard: Not; Min Operating Temperature: -55°C; Mount: Surface Mount; Number of Terminations: 2; Package Quantity: 1; Packaging: Tape and Reel; Power Rating: 100mW; Pricing 1: 1=0.1, 10=0.045, 100=0.0184, 1000=0.00826, 2500=0.00717, 5000=0.00593 (USD); Radiation Hardening: No; REACH SVHC: No SVHC; Signal Integrity: Res; Simulation: RES; Stock 1: 642946; Supplier 1: Digi-Key; Supplier Part Number 1: P5.62KLDKR-ND; Termination: SMD/SMT; Tolerance: 1%; Voltage Rating (DC): 50V; Width: 0.02inch
R9 = Res: RES 10K OHM 1/16W 1% 0402 SMD; Manufacturer: Panasonic; Manufacturer Part Number: ERJ-2RKF1002X; Max Power Dissipation: 100mW; Resistance: 10kR; Temperature Coefficient: 100ppm/°C; Voltage Rating: 50V; Case Code (Imperial): 0402; Case Code (Metric): 1005; Case/Package: 0402; Component Kind: Standard; ComponentLink1Description: http://www.yageo.com/documents/recent/PYu-RC0402_51_RoHS_L_6.pdf; ComponentLink1URL: http://www.yageo.com/documents/recent/PYu-RC0402_51_RoHS_L_6.pdf; Composition: Thick Film; Contact Plating: Tin; Depth: 0.5mm; Features: Automotive AEC-Q200; Height: 0.35mm; LatestRevisionDate: 2-Dec-2009; Lead Free: Lead Free; Length: 1mm; Library Name: General.SchLib; Max Operating Temperature: 155°C; Military Standard: Not; Min Operating Temperature: -55°C; Mount: Surface Mount; Number of Pins: 2; Number of Terminations: 2; Package Quantity: 1; Packaging: Tape and Reel; Power Rating: 100mW; Pricing 1: 1=0.1, 10=0.045, 100=0.0184, 1000=0.00826, 2500=0.00717, 5000=0.00593 (USD); Radiation Hardening: No; REACH SVHC: No SVHC; Signal Integrity: Res; Simulation: RES; Stock 1: 28312782; Supplier 1: Digi-Key; Supplier Part Number 1: P10.0KLDKR-ND; Termination: SMD/SMT; Tolerance: 1%; Voltage Rating (DC): 50V; Width: 0.02inch
R10 R28-R32 R36 R40 R42 R46 R55 R56 = Res: RES SMD 470 OHM 5% 1/16W 0402; Manufacturer: Vishay Dale; Manufacturer Part Number: CRCW0402470RJNED; Max Power Dissipation: 63mW; Temperature Coefficient: 200ppm/°C; Voltage Rating: 50V; Case Code (Imperial): 0402; Case Code (Metric): 1005; Case/Package: 0402; Component Kind: Standard; Composition: Thick Film; Contact Plating: Tin; Depth: 0.5mm; Features: Automotive AEC-Q200; Height: 0.35mm; Lead Free: Lead Free; Length: 1mm; Library Name: General.SchLib; Max Operating Temperature: 155°C; Military Standard: Not; Min Operating Temperature: -55°C; Number of Pins: 2; Number of Terminations: 2; Package Quantity: 1; Packaging: Tape and Reel; Power Rating: 63mW; Pricing 1: 1=0.1, 10=0.031, 100=0.0125, 1000=0.00563, 2500=0.00488, 5000=0.00403 (USD); Radiation Hardening: No; REACH SVHC: Unknown; Signal Integrity: Res; Simulation: RES; Stock 1: 1075; Supplier 1: Digi-Key; Supplier Part Number 1: 541-470JDKR-ND; Termination: SMD/SMT; Tolerance: 5%; Voltage Rating (DC): 50V; Weight: 2.3E-05oz; Width: 0.02inch
R53 = Res: RES 1.00K OHM 1/16W 1% 0402 SMD; Power (Watts): 0.063W, 1/16W; Category: Resistors; Component Kind: Standard; ComponentLink1Description: http://www.yageo.com/documents/recent/PYu-RC0402_51_RoHS_L_6.pdf; ComponentLink1URL: http://www.yageo.com/documents/recent/PYu-RC0402_51_RoHS_L_6.pdf; Composition: Thick Film; Features: -; Height: 0.016" (0.40mm); Library Name: General.SchLib; Number of Terminations: 2; Package / Case: 0402 (1005 Metric); Packaging: Cut Tape (CT); Pricing 1: 1=0.1, 10=0.014, 100=0.0063, 500=0.00382, 1000=0.0027, 5000=0.00203 (USD); RoHS: RoHS Compliant; Signal Integrity: Res; Simulation: RES; Size / Dimension: 0.039" L x 0.020" W (1.00mm x 0.50mm); Stock 1: 3222170
R54 = Res: RES SMD 1M OHM 1% 1/16W 0402; Manufacturer: Yageo; Manufacturer Part Number: RC0402FR-071ML; Power Rating: 63mW; Resistance: 1MR; Temperature Coefficient: 100ppm/°C; Voltage Rating: 50V; Case Code (Imperial): 0402; Case Code (Metric): 1005; Case/Package: 0402; Component Kind: Standard; ComponentLink1Description: https://datasheet.ciiva.com/11808/0900766b80c66297-11808125.pdf?src-supplier=RSComponents; ComponentLink1URL: https://datasheet.ciiva.com/11808/0900766b80c66297-11808125.pdf?src-supplier=RSComponents; ComponentLink2Description: https://datasheet.ciiva.com/46394/1018594-46394951.pdf?src-supplier=Element14; ComponentLink2URL: https://datasheet.ciiva.com/46394/1018594-46394951.pdf?src-supplier=Element14; ComponentLink3Description: https://datasheet.ciiva.com/16741/pyu-rc0402-51-rohs-l-6-r-16741081.pdf?src-supplier=Future+Electronics; ComponentLink3URL: https://datasheet.ciiva.com/16741/pyu-rc0402-51-rohs-l-6-r-16741081.pdf?src-supplier=Future+Electronics; ComponentLink4Description: https://datasheet.ciiva.com/7849/1018594-7849882.pdf?src-supplier=Element14; ComponentLink4URL: https://datasheet.ciiva.com/7849/1018594-7849882.pdf?src-supplier=Element14; ComponentLink5Description: https://datasheet.ciiva.com/7849/1018594-7849883.pdf?src-supplier=Element14; ComponentLink5URL: https://datasheet.ciiva.com/7849/1018594-7849883.pdf?src-supplier=Element14; Composition: Thick Film; Depth: 0.5mm; Height: 0.32mm; Lead Free: Lead Free; Length: 1mm; Library Name: General.SchLib; Max Operating Temperature: 155°C; Military Standard: Not; Min Operating Temperature: -55°C; Packaging: Tape and Reel; Pricing 1: 1=0.10000, 10=0.01600, 100=0.00670, 1000=0.00301, 2500=0.00261, 5000=0.00215 (USD); REACH SVHC: Unknown; RoHS: Yes; Signal Integrity: Res; Simulation: RES; Stock 1: 13180955; Supplier 1: Digi-Key; Supplier Part Number 1: 311-1.00MLRCT-ND; Termination: SMD/SMT; Tolerance: 1%
S1-S3 = Switch SPST: SWITCH TACTILE SPST-NO 0.05A 16V; Manufacturer: ITT C&K; Manufacturer Part Number: PTS810SJK250SMTRLFS; Actuator Type: Standard; Current Rating: 50mA; Illumination Type: Non-Illuminated; Mechanical Life: 50000Cycles; Operating Force: 260gf; Switch Function: Off-Mom; Switch Travel: 0.15mm; Case/Package: SMD/SMT; Colour: White; Component Kind: Standard; ComponentLink1Description: https://www.ckswitches.com/media/1476/pts810.pdf; ComponentLink1URL: https://www.ckswitches.com/media/1476/pts810.pdf; Contact Plating: Silver; Depth: 4.6mm; Lead Free: Lead Free; Length: 3.2mm; Library Name: General.SchLib; Max Current Rating: 50mA; Max Operating Temperature: 85°C; Min Operating Temperature: -40°C; Mount: Surface Mount; Orientation: Straight; Package Quantity: 1; Packaging: Tape and Reel; Pricing 1: 1=0.3, 10=0.287, 25=0.2768, 50=0.267, 100=0.2521, 250=0.23728, 500=0.2274, 1000=0.17302 (USD); Stock 1: 85168; Supplier 1: Digi-Key; Supplier Part Number 1: CKN10503DKR-ND; Termination: J-Bend; Throw Configuration: SPST; Voltage Rating (DC): 16V
U2 = SN74LVC2G86DCUR: IC GATE XOR 2CH 2-INP US8; Manufacturer: Texas Instruments; 3-State Output: No; Bits(#): 2; F @ Nom Voltage(Max)(Mhz): 150; High Level Output Current: -32mA; ICC @ Nom Voltage(Max)(mA): 0.01; Input Type: CMOS/TTL; Logic: True; Logic Function: OR,XOR; Low Level Output Current: 32mA; Max Supply Voltage: 5.5V; Min Supply Voltage: 1.65V; Number of Output Lines: 1; Output Current: 50mA; Output Drive (IOL/IOH)(Max)(mA): 32/-32; Output Type: CMOS; Propagation Delay: 3.6ns; Quiescent Current: 10uA; Rating: Catalog; Sub-Family: XOR Gate; Technology Family: LVC; tpd @ Nom Voltage(Max)(ns): 11,6.7,5.6,4.2; Turn-On Delay Time: 4.7ns; VCC(Max)(V): 5.5; VCC(Min)(V): 1.65; Voltage(Nom)(V): 1.8,2.5,3.3,5; Case/Package: VSSOP; Component Kind: Standard; ComponentLink1Description: Manufacturer URL; ComponentLink1URL: http://www.ti.com/; ComponentLink2Description: Datasheet; ComponentLink2URL: http://www.ti.com/lit/ds/symlink/sn74lvc2g86.pdf; Contact Plating: Gold; DatasheetVersion: SCES360I; Generic Part Number: SN74LVC2G86; Height: 0.85mm; Lead Free: Lead Free; Length: 2.3mm; Library Name: TI_Logic_Little_Logic.IntLib; Manufacturer Part Number: SN74LVC2G86DCUR; Max Operating Temperature: 125°C; Min Operating Temperature: -40°C; Mount: Surface Mount; Number of Bits: 2; Number of Circuits: 2; Number of Elements: 2; Number of Gates: 2; Number of Input Lines: 2; Number of Inputs: 2; Number of Outputs: 1; Number of Pins: 8; Operating Temperature Range(C): -40 to 125,-40 to 85; Package Quantity: 1; PackageReference: DCU0008A; Packaging: Tape and Reel; PartNumber: SN74LVC2G86DCUR; Pricing 4: 1=0.55, 10=0.467, 100=0.349, 500=0.27418, 1000=0.21187 (USD); Radiation Hardening: No; REACH SVHC: No SVHC; Schmitt Trigger: No; Schmitt Trigger Input: No; Stock 4: 7530; Supplier 1: Mouser; Supplier 2: Digi-Key; Supplier 3: Digi-Key; Supplier 4: Digi-Key; Supplier Part Number 1: 595-SN74LVC2G86DCUR; Supplier Part Number 2: 296-13275-2-ND; Supplier Part Number 3: 296-13275-1-ND; Supplier Part Number 4: 296-13275-6-ND; Weight: 0.000339oz; Width: 2mm
U3 = ATSAME70-144: IC MCU 32BIT 1MB FLASH 144LFBGA; Manufacturer: Microchip; Manufacturer Part Number: ATSAME70Q20B-CNT; Component Kind: Standard; ComponentLink1Description: Digikey; ComponentLink1URL: https://www.digikey.com/product-detail/en/microchip-technology/ATSAME70Q20B-CNT/ATSAME70Q20B-CNTCT-ND/8275605; Library Name: ICs.SchLib; Pricing 1: 1=12.84, 25=11.6804, 100=10.5782 (USD); Stock 1: 2000; Supplier 1: Digi-Key; Supplier Part Number 1: ATSAME70Q20B-CNTDKR-ND
Y1 = Crystal: CRYSTAL 32.7680KHZ 9PF SMD; Manufacturer: Abracon LLC; Manufacturer Part Number: ABS06-32.768KHZ-9-1-T; ESR (Equivalent Series Resistance): 90 kOhm; Frequency Tolerance: ±10ppm; Operating Mode: Fundamental; Category: Crystals and Oscillators; Component Kind: Standard; ComponentLink1Description: http://www.abracon.com/Resonators/ABS06.pdf; ComponentLink1URL: http://www.abracon.com/Resonators/ABS06.pdf; Frequency: 32.768kHz; Frequency Stability: -; Height: 0.024" (0.60mm); Library Name: General.SchLib; Load Capacitance: 9pF; Mounting Type: Surface Mount; Operating Temperature: -40°C ~ 85°C; Package / Case: 2-SMD; Packaging: Cut Tape (CT); Pricing 1: 1=1.2, 10=1.153, 50=1.1272, 100=0.9639, 500=0.9384, 1000=0.7905 (USD); Ratings: -; RoHS: RoHS Compliant; Size / Dimension: 0.079" L x 0.047" W (2.00mm x 1.20mm); Stock 1: 12198; Supplier 1: Digi-Key; Supplier Part Number 1: 535-10247-1-ND; Type: kHz Crystal (Tuning Fork)
Y2 = Oscillator2: OSC XO 12.000MHZ HCMOS SMD; Manufacturer: ECS Inc.; Manufacturer Part Number: ECS-2520S33-120-FN-TR; Current - Supply (Disable) (Max): 10µA; Current - Supply (Max): 7mA; Frequency Stability: ±10ppm; Function: Standby (Power Down); Voltage - Supply: 3.3 V; Category: Crystals and Oscillators; Component Kind: Standard; ComponentLink1Description: https://www.ecsxtal.com/store/pdf/ECS-2520S.pdf; ComponentLink1URL: https://www.ecsxtal.com/store/pdf/ECS-2520S.pdf; Height: 0.039" (1.00mm); Library Name: General.SchLib; Mounting Type: Surface Mount; Operating Temperature: -40°C ~ 85°C; Output: HCMOS; Package / Case: 4-SMD, No Lead (DFN, LCC); Packaging: Tape & Reel (TR); Pricing 1: 1000=0.85, 3000=0.84, 5000=0.81 (USD); Ratings: -; RoHS: RoHS Compliant; Size / Dimension: 0.098" L x 0.079" W (2.50mm x 2.00mm); Stock 1: 1641; Supplier 1: Digi-Key; Supplier Part Number 1: XC2194TR-ND; Type: XO (Standard)
